# Altium schematic sheet STM32WB09_QFN32_RefBoard_SMD_2Layers.SchDoc(STM32WB09_QFN32_RefBoard_SMD_2Layers)
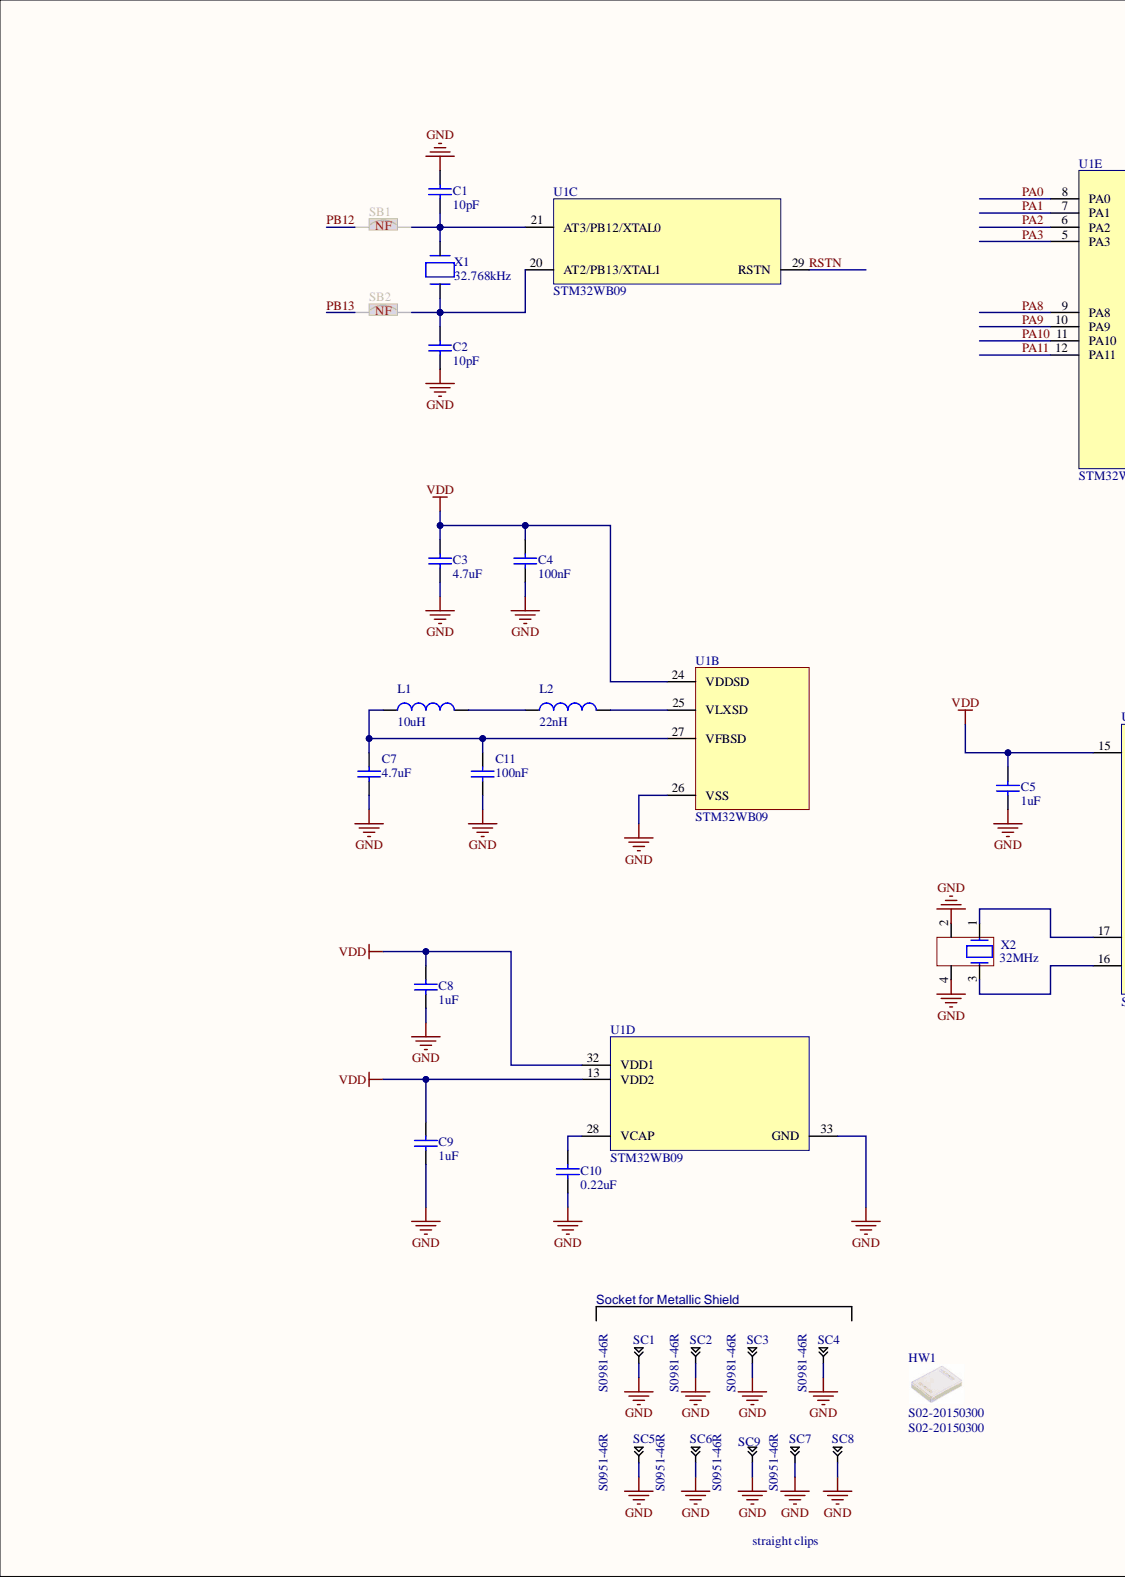
[diagram p0: STM32WB09_QFN32_RefBoard_SMD_2Layers.SchDoc, left]
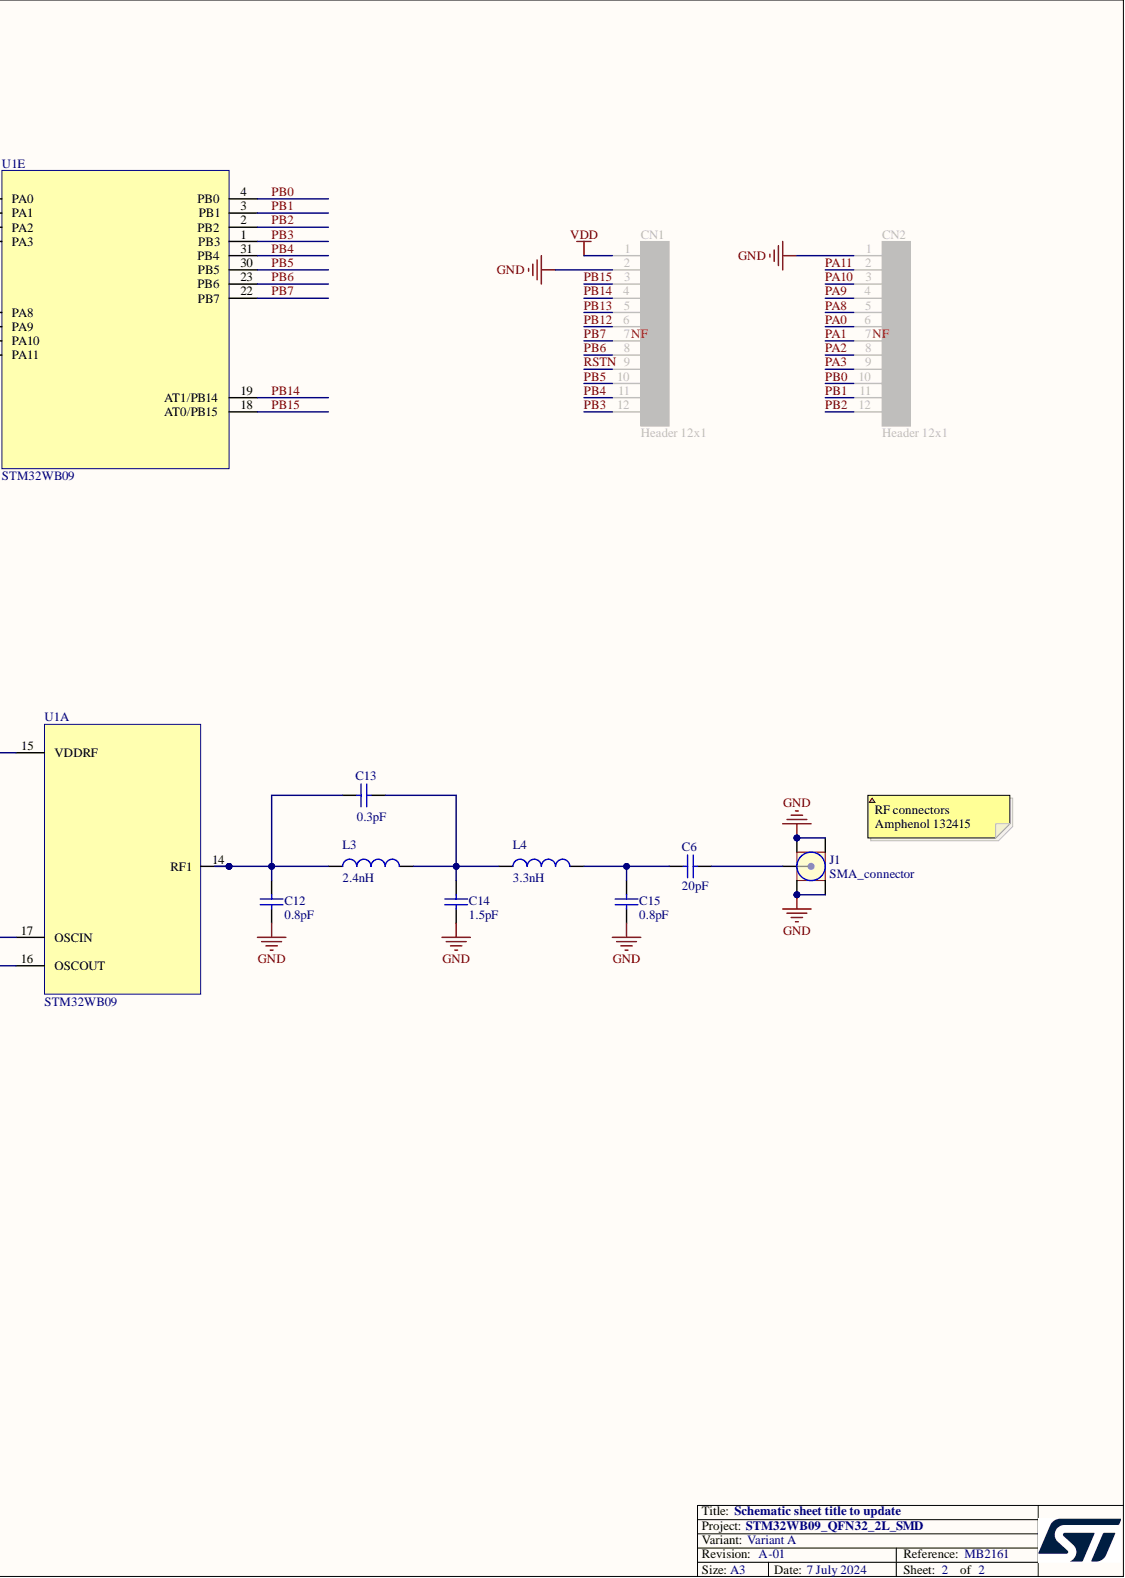
[diagram p1: STM32WB09_QFN32_RefBoard_SMD_2Layers.SchDoc, right]

## Parts
C1  value 10pF  [C0402]  (hidden comment: Capacitor)
C2  value 10pF  [C0402]  (hidden comment: Capacitor)
C3  value 4.7uF  [C0402]  (hidden comment: Capacitor)
C4  value 100nF  [C0201_H0P3]  (hidden comment: Capacitor)
C5  value 1uF  [C0402]  (hidden comment: Capacitor)
C6  value 20pF  [20p_capacitor_SMD_0201]  (hidden comment: Capacitor)
C7  value 4.7uF  [C0402]  (hidden comment: Capacitor)
C8  value 1uF  [C0402]  (hidden comment: Capacitor)
C9  value 1uF  [C0402]  (hidden comment: Capacitor)
C10  value 0.22uF  [C0402]  (hidden comment: Capacitor)
C11  value 100nF  [C0402]  (hidden comment: Capacitor)
C12  value 0.8pF  [0_8p_capacitor_SMD_0201]  (hidden comment: Capacitor)
C13  value 0.3pF  [0_5p_capacitor_SMD_0201]  (hidden comment: Capacitor)
C14  value 1.5pF  [1_5p_capacitor_SMD_0201]  (hidden comment: Capacitor)
C15  value 0.8pF  [0_8p_capacitor_SMD_0201]  (hidden comment: Capacitor)
CN1  Header 12x1  [CON_SAMTEC_TSW-112-07-X-S]
CN2  Header 12x1  [CON_SAMTEC_TSW-112-07-X-S]
HW1  S02-20150300  [SHIELD_HARWIN_S02-20150300_15X20_H3]
J1  SMA_connector  [SMA_AMPHENOL_132415]
L1  value 10uH  [IND_0805_MURATA_LQM21]  (hidden comment: Inductor)
L2  value 22nH  [C0402]  (hidden comment: Inductor)
L3  value 2.4nH  [2n4_inductor_SMD_0201]  (hidden comment: Inductor)
L4  value 3.3nH  [3n3_inductor_SMD_0201]  (hidden comment: Inductor)
SB1  SB_ON  [SOLDER-BRIDGE_R0402-0R]  (value not drawn)
SB2  SB_ON  [SOLDER-BRIDGE_R0402-0R]  (value not drawn)
SC1  value S0981-46R  Manufacturer_Part_Number: S0981-46R  [CLIP_HARWIN_S0981-46R]  (hidden comment: Shield-clip_S0951-46R)
SC2  value S0981-46R  Manufacturer_Part_Number: S0981-46R  [CLIP_HARWIN_S0981-46R]  (hidden comment: Shield-clip_S0951-46R)
SC3  value S0981-46R  Manufacturer_Part_Number: S0981-46R  [CLIP_HARWIN_S0981-46R]  (hidden comment: Shield-clip_S0951-46R)
SC4  value S0981-46R  Manufacturer_Part_Number: S0981-46R  [CLIP_HARWIN_S0981-46R]  (hidden comment: Shield-clip_S0951-46R)
SC5  value S0951-46R  Manufacturer_Part_Number: S0951-46R  [CLIP_HARWIN_S0951-46R]  (hidden comment: Shield-clip_S0951-46R)
SC6  value S0951-46R  Manufacturer_Part_Number: S0951-46R  [CLIP_HARWIN_S0951-46R]  (hidden comment: Shield-clip_S0951-46R)
SC7  value S0951-46R  Manufacturer_Part_Number: S0951-46R  [CLIP_HARWIN_S0951-46R]  (hidden comment: Shield-clip_S0951-46R)
SC8  Shield-clip_S0951-46R  [CLIP_HARWIN_S0951-46R]  (value not drawn)
SC9  value S0951-46R  Manufacturer_Part_Number: S0951-46R  [CLIP_HARWIN_S0951-46R]  (hidden comment: Shield-clip_S0951-46R)
U1  STM32WB09  [ICC_QFN32-EPAD_5X5_P050]  (parts A,B,C,D,E)
X1  value 32.768kHz  [CRY_NX2012SA_2P]  (hidden comment: XTAL_2_PINS)
X2  value 32MHz  [CRY_NX2016SA_4P]  (hidden comment: XTAL_4_PINS)

## Nets
GND: C1.2 C2.1 C3.1 C4.1 C5.1 C7.1 C8.1 C9.1 C10.1 C11.1 C12.1 C14.1 C15.1 CN1.2 CN2.1 J1.2 SC1.1 SC2.1 SC3.1 SC4.1 SC5.1 SC6.1 SC7.1 SC8.1 SC9.1 U1.26(VSS) U1.33(GND) X2.2 X2.4
VDD: C3.2 C4.2 C5.2 C8.2 C9.2 CN1.1 U1.13(VDD2) U1.15(VDDRF) U1.24(VDDSD) U1.32(VDD1)
PA0: CN2.6 U1.8(PA0)
PA1: CN2.7 U1.7(PA1)
PA2: CN2.8 U1.6(PA2)
PA3: CN2.9 U1.5(PA3)
PA8: CN2.5 U1.9(PA8)
PA9: CN2.4 U1.10(PA9)
PA10: CN2.3 U1.11(PA10)
PA11: CN2.2 U1.12(PA11)
PB0: CN2.10 U1.4(PB0)
PB1: CN2.11 U1.3(PB1)
PB2: CN2.12 U1.2(PB2)
PB3: CN1.12 U1.1(PB3)
PB4: CN1.11 U1.31(PB4)
PB5: CN1.10 U1.30(PB5)
PB6: CN1.8 U1.23(PB6)
PB7: CN1.7 U1.22(PB7)
PB12: CN1.6 SB1.1
PB13: CN1.5 SB2.1
PB14: CN1.4 U1.19(AT1/PB14)
PB15: CN1.3 U1.18(AT0/PB15)
RSTN: CN1.9 U1.29(RSTN)
NetC1_1: C1.1 SB1.2 U1.21(AT3/PB12/XTAL0) X1.1
NetC2_2: C2.2 SB2.2 U1.20(AT2/PB13/XTAL1) X1.2
NetC6_1: C6.1 C15.2 L4.2
NetC6_2: C6.2 J1.1
NetC7_2: C7.2 C11.2 L1.1 U1.27(VFBSD)
NetC10_2: C10.2 U1.28(VCAP)
NetC12_2: C12.2 C13.1 L3.1 U1.14(RF1)
NetC13_2: C13.2 C14.2 L3.2 L4.1
NetL1_2: L1.2 L2.1
NetL2_2: L2.2 U1.25(VLXSD)
NetU1_16: U1.16(OSCOUT) X2.3
NetU1_17: U1.17(OSCIN) X2.1

## Library data of the parts
C1 C2 = MCD-00015: Capacitor, MLCC, 10pF, 50V, NPO, +/-5%, 0402, T=0.5mm; Manufacturer: YAGEO; Manufacturer_Part_Number: CC0402JRNPO9BN100; Part_Preference: Recommended; Component_Country_Of_Origin: China; Database Table Name: MCD_PARTS$; ID: 2465; Order_Code_RS: 3019160RL; ShowFields: YES; Component Kind: Standard; ComponentLink1URL: http://tunmcdsvn.tun.st.com/Component_Documentation_Library/Trunk/Capacitor/YAGEO_NP0_Series; Internal_Part_Number: MCD-00015; Library Name: MCD_PARTS_database.DbLib; Order_Code_Digikey: 311-1014-1-ND; Order_Code_Farnell: 3019160RL; Package: 0402; Part_Type: Capacitor; Status: Released
C3 C7 = MCD-03431: Capacitor, MLCC, 4.7uF, X5R, 10V, +/-20%, 0402, T=0.5mm; Manufacturer: MURATA; Manufacturer_Part_Number: GRM155R61A475MEAAD; Part_Preference: Recommended; Component_Country_Of_Origin: China, Japan, Philippines, Singapore; Database Table Name: MCD_PARTS$; ID: 5547; Order_Code_RS: 172-6870; ShowFields: YES; Component Kind: Standard; ComponentLink1URL: http://tunmcdsvn.tun.st.com/Component_Documentation_Library/Trunk/Capacitor/MURATA_GRM_Series/GRM155R61A475MEAAD; Internal_Part_Number: MCD-03431; Library Name: MCD_PARTS_database.DbLib; Order_Code_Digikey: 90-14306-2-ND; Order_Code_Farnell: 2688503RL; Package: 0402; Part_Type: Capacitor; Status: Checked
C4 = MCD-01535: Capacitor, MLCC,100nF, 10V, X5R, +/-10%, 0201, T=0.3mm; Manufacturer: MURATA; Manufacturer_Part_Number: GRM033R61A104KE15; Part_Preference: Recommended; Component_Country_Of_Origin: Japan; Database Table Name: MCD_PARTS$; ID: 3635; Order_Code_RS: 790-2742; ShowFields: YES; Component Kind: Standard; ComponentLink1URL: http://tunmcdsvn.tun.st.com/Component_Documentation_Library/Trunk/Capacitor/MURATA_GRM_Series; Internal_Part_Number: MCD-01535; Library Name: MCD_PARTS_database.DbLib; Order_Code_Digikey: 490-5881-1-ND; Order_Code_Farnell: 2470450; Package: 0201; Part_Type: Capacitor; Status: Released
C5 C8 C9 = MCD-03432: Capacitor, MLCC, 1uF, X7R, 6.3V,+/- 10%, 0402, T=0.5mm; Manufacturer: MURATA; Manufacturer_Part_Number: GRM155R70J105KA12; Part_Preference: Recommended; Component_Country_Of_Origin: China, Japan, Philippines, Singapore; Database Table Name: MCD_PARTS$; ID: 5548; Order_Code_RS: 113-8711; ShowFields: YES; Component Kind: Standard; ComponentLink1URL: http://tunmcdsvn.tun.st.com/Component_Documentation_Library/Trunk/Capacitor/MURATA_GRM_Series/GRM155R70J105KA12; Internal_Part_Number: MCD-03432; Library Name: MCD_PARTS_database.DbLib; Order_Code_Digikey: NA; Order_Code_Farnell: NA; Package: 0402; Part_Type: Capacitor; Status: Checked
C6 = MCD-03543: Capacitor, MLCC, 20pF, 25V, COG, +/-2%, 0201, T=0.3mm; Manufacturer: MURATA; Manufacturer_Part_Number: GJM0335C1E200GB01; Part_Preference: Recommended; Component_Country_Of_Origin: Japan, Singapore; ID: 5659; ShowFields: YES; Component Kind: Standard; ComponentLink1URL: http://tunmcdsvn.tun.st.com/Component_Documentation_Library/Trunk/Capacitor/MURATA_GJM_Series; Internal_Part_Number: MCD-03543; Order_Code_Digikey: 490-GJM0335C1E200GB01DTR-ND; Order_Code_Farnell: 2994012; Order_Code_RS: N/A; Package: 0201; Part_Type: Capacitor; Status: Checked; Library Name: missing_parts.SchLib
C10 = MCD-03483: Capacitor, MLCC, 0.22uF, X5R, 6.3V, +/-10%, 0402, T=0.5mm; Manufacturer: YAGEO; Manufacturer_Part_Number: CC0402KRX5R5BB224; Part_Preference: Recommended; Component_Country_Of_Origin: China; Database Table Name: MCD_PARTS$; ID: 5599; Order_Code_RS: 3369131; ShowFields: YES; Component Kind: Standard; ComponentLink1URL: http://tunmcdsvn.tun.st.com/Component_Documentation_Library/Trunk/Capacitor/YAGEO_X5R_Series; Internal_Part_Number: MCD-03483; Library Name: MCD_PARTS_database.DbLib; Order_Code_Digikey: 311-1681-2-ND; Order_Code_Farnell: 3369131; Package: 0402; Part_Type: Capacitor; Status: Checked
C11 = MCD-03442: Capacitor, MLCC, 100nF, +/-10%, X7R, 25V, 0402, T=0.5mm; Manufacturer: MURATA; Manufacturer Part Number: GRM155R71E104KE14D; Part_Preference: Recommended; Component_Country_Of_Origin: China, Japan, Philippines, Singapore; Database Table Name: MCD_PARTS$; ID: 5558; Order_Code_RS: NA; ShowFields: YES; Component Kind: Standard; ComponentLink1URL: http://tunmcdsvn.tun.st.com/Component_Documentation_Library/Trunk/Capacitor/MURATA_GRM_Series/GRM155R71E104KE14; Internal_Part_Number: MCD-03442; Library Name: MCD_PARTS_database.DbLib; Manufacturer_Part_Number: GRM155R71E104KE14D; Order_Code_Digikey: 490-10698-2-ND; Order_Code_Farnell: NA; Package: 0402; Part_Type: Capacitor; Status: Checked
C12 C15 = MCD-02284_1: Multilayer Ceramic Capacitor, 0.8 pF, 25 V, ± 0.05pF, C0G (NP0), 0201 [0603 Metric]; Manufacturer: Murata; Manufacturer Part Number: GJM0335C1ER80WB01D; Material: 4241ConductorMaterial; Temperature Coefficient: 30ppm/°C; Category: Ceramic Capacitors; Component Kind: Standard; ComponentLink1URL: http://tunmcdsvn.tun.st.com/Component_Documentation_Library/Trunk/Capacitor/MURATA_GRM_Series; ComponentLink2Description: https://datasheet.ciiva.com/11616/product-11616837.pdf?src-supplier=Avnet; ComponentLink2URL: https://datasheet.ciiva.com/11616/product-11616837.pdf?src-supplier=Avnet; ComponentLink3Description: https://datasheet.ciiva.com/170/partnumbering-e-01-170845.pdf?src-supplier=Digi-Key; ComponentLink3URL: https://datasheet.ciiva.com/170/partnumbering-e-01-170845.pdf?src-supplier=Digi-Key; Manufacturer_Part_Number: GJM0335C1ER80WB01D; Package: 0201; Pricing 1: 1=0.072, 10=0.0302, 25=0.0296, 100=0.0178, 250=0.0176, 500=0.0175, 1000=0.0173, 3000=0.0172, 6000=0.0171, 15000=0.0169 (USD); RoHS: Yes; Stock 1: 23495; Supplier 1: Arrow Electronics; Supplier Part Number 1: GJM0335C1ER80WB01D; Library Name: missing_parts.SchLib
C13 = MCD-02284_4: 0201 0.3 pF 25 V ±0.05 pF Tolerance C0G SMT Multilayer Ceramic Capacitor; Manufacturer: Murata; Manufacturer Part Number: GJM0335C1ER30WB01D; Category: Ceramic Capacitors; Component Kind: Standard; ComponentLink1URL: http://tunmcdsvn.tun.st.com/Component_Documentation_Library/Trunk/Capacitor/MURATA_GRM_Series; ComponentLink2Description: https://datasheet.ciiva.com/11617/product-11617172.pdf?src-supplier=Avnet; ComponentLink2URL: https://datasheet.ciiva.com/11617/product-11617172.pdf?src-supplier=Avnet; ComponentLink3Description: https://datasheet.ciiva.com/29541/partnumbering-e-01-29541903.pdf?src-supplier=Digi-Key; ComponentLink3URL: https://datasheet.ciiva.com/29541/partnumbering-e-01-29541903.pdf?src-supplier=Digi-Key; ComponentLink4Description: https://datasheet.ciiva.com/29541/partnumbering-e-01-29541902.pdf?src-supplier=Digi-Key; ComponentLink4URL: https://datasheet.ciiva.com/29541/partnumbering-e-01-29541902.pdf?src-supplier=Digi-Key; ComponentLink5Description: https://datasheet.ciiva.com/170/gjm0335c1er30wb01-170840.pdf?src-supplier=Digi-Key; ComponentLink5URL: https://datasheet.ciiva.com/170/gjm0335c1er30wb01-170840.pdf?src-supplier=Digi-Key; ComponentLink6Description: https://datasheet.ciiva.com/11617/product-11617570.pdf?src-supplier=Avnet; ComponentLink6URL: https://datasheet.ciiva.com/11617/product-11617570.pdf?src-supplier=Avnet; ComponentLink7Description: https://datasheet.ciiva.com/16467/product-16467435.pdf?src-supplier=Future+Electronics; ComponentLink7URL: https://datasheet.ciiva.com/16467/product-16467435.pdf?src-supplier=Future+Electronics; ComponentLink8Description: https://datasheet.ciiva.com/29541/partnumbering-e-01-29541493.pdf?src-supplier=Digi-Key; ComponentLink8URL: https://datasheet.ciiva.com/29541/partnumbering-e-01-29541493.pdf?src-supplier=Digi-Key; ComponentLink9Description: https://datasheet.ciiva.com/29541/partnumbering-e-01-29541494.pdf?src-supplier=Digi-Key; ComponentLink9URL: https://datasheet.ciiva.com/29541/partnumbering-e-01-29541494.pdf?src-supplier=Digi-Key; ComponentLink10Description: https://datasheet.ciiva.com/29541/partnumbering-e-01-29541495.pdf?src-supplier=Digi-Key; ComponentLink10URL: https://datasheet.ciiva.com/29541/partnumbering-e-01-29541495.pdf?src-supplier=Digi-Key; Manufacturer_Part_Number: GJM0335C1ER30WB01D; Package: 0201; Pricing 1: 1=0.14, 10=0.096, 50=0.0574, 100=0.0451, 500=0.0333, 1000=0.02757, 2500=0.02566, 5000=0.02347 (USD); RoHS: Yes; Stock 1: 304885; Supplier 1: Digi-Key; Supplier Part Number 1: 490-8073-1-ND; Library Name: missing_parts.SchLib
C14 = MCD-02284_3: Multilayer Ceramic Capacitor, 1.5 pF, 25 V, ± 0.1pF, C0G (NP0), 0201 [0603 Metric]; Manufacturer: Murata; Manufacturer Part Number: GJM0335C1E1R5BB01D; Voltage Rating: 25V; Case Code (Imperial): 0201; Case Code (Metric): 0603; Case/Package: 0201; Category: Ceramic Capacitors; Component Kind: Standard; ComponentLink1URL: http://tunmcdsvn.tun.st.com/Component_Documentation_Library/Trunk/Capacitor/MURATA_GRM_Series; ComponentLink2Description: https://datasheet.ciiva.com/11616/product-11616837.pdf?src-supplier=Avnet; ComponentLink2URL: https://datasheet.ciiva.com/11616/product-11616837.pdf?src-supplier=Avnet; ComponentLink3Description: https://datasheet.ciiva.com/170/partnumbering-e-01-170845.pdf?src-supplier=Digi-Key; ComponentLink3URL: https://datasheet.ciiva.com/170/partnumbering-e-01-170845.pdf?src-supplier=Digi-Key; ComponentLink4Description: https://datasheet.ciiva.com/11615/product-11615773.pdf?src-supplier=Avnet; ComponentLink4URL: https://datasheet.ciiva.com/11615/product-11615773.pdf?src-supplier=Avnet; ComponentLink5Description: https://datasheet.ciiva.com/18183/getdatasheetpartid-480423-18183785.pdf?src-supplier=Verical; ComponentLink5URL: https://datasheet.ciiva.com/18183/getdatasheetpartid-480423-18183785.pdf?src-supplier=Verical; Construction: Flat; Depth: 0.3mm; Dielectric: C0G; Height: 0.3mm; Lead Free: Lead Free; Length: 0.6mm; Manufacturer_Part_Number: GJM0335C1E1R5BB01D; Max Operating Temperature: 125°C; Min Operating Temperature: -55°C; Mounting Technology: Surface Mount; Package: 0201; Package Quantity: 15000; Packaging: Tape and Reel; Pricing 1: 1=0.1, 10=0.069, 50=0.0376, 100=0.0308, 500=0.02198, 1000=0.01727, 2500=0.0157, 5000=0.01444 (USD); Radiation Hardening: No; RoHS: Yes; RoHS Compliant: Yes; Series: GJM; Stock 1: 1582621; Supplier 1: Digi-Key; Supplier Part Number 1: 490-11175-1-ND; Termination: SMD/SMT; Thickness: 0.013inch; Voltage Rating (DC): 25V; Width: 0.012inch; Library Name: missing_parts.SchLib
CN1 CN2 = MCD-01795: Header, 12x1, 2.54mm, VR, TH, T=5.84mm/2.54mm; Manufacturer: SAMTEC; Manufacturer_Part_Number: TSW-112-07-T-S; Part_Preference: Recommended; Part_Type: Connector; Component_Country_Of_Origin: China, Costa Rica, Malaysia, United States, Vietnam; Database Table Name: MCD_PARTS$; ID: 3895; Order_Code_RS: 180-2023; ShowFields: YES; Component Kind: Standard; ComponentLink1URL: http://tunmcdsvn.tun.st.com/Component_Documentation_Library/Trunk/Connector/SAMTEC_TSW_Series/; Internal_Part_Number: MCD-01795; Library Name: MCD_PARTS_database.DbLib; Order_Code_Digikey: MTSW-112-07-T-S-007-ND; Order_Code_Farnell: 2035692; Status: Released; Value: Header 12x1
HW1 = MCD-03126: RFI shield can, 20x15x3mm; Manufacturer: HARWIN; Part_Preference: Recommended; Part_Type: Misc; Component_Country_Of_Origin: UK°; Database Table Name: MCD_PARTS$; ID: 5242; Order_Code_RS: 161-3687; ShowFields: YES; Component Kind: Standard; ComponentLink1URL: http://tunmcdsvn.tun.st.com/Component_Documentation_Library/Trunk/Misc/HARWIN_S02-20150300; Internal_Part_Number: MCD-03126; Library Name: MCD_PARTS_database.DbLib; Manufacturer_Part_Number: S02-20150300; Order_Code_Digikey: 952-2632-ND; Order_Code_Farnell: 3755471; Package: 20x15x3mm; Status: Checked; Value: S02-20150300
J1 = SMA_connector: Rf Connector, Sma End Launch Recept Jack For .032" Pcb / Individual Bag; Manufacturer: Amphenol Connex; Manufacturer Part Number: 132415; Note: Replacement only with Johnson 142-0791-811; Category: RF / Coaxial Connectors; Component Kind: Standard; ComponentLink1Description: https://datasheet.ciiva.com/13668/132415-57564-13668389.pdf?src-supplier=Mouser; ComponentLink1URL: https://datasheet.ciiva.com/13668/132415-57564-13668389.pdf?src-supplier=Mouser; Manufacturer_Part_Number: 132415; Pricing 1: 1=12.78, 10=11.327, 25=10.8916, 50=10.4558, 100=9.875, 250=9.00364, 500=8.42276, 1000=7.7257 (USD); RoHS: Yes; Stock 1: 22; Supplier 1: Digi-Key; Supplier Part Number 1: 132415-ND; Library Name: missing_parts.SchLib
L1 = MCD-03438: Inductor, MLCC, 10uH, +/-20%, 300mA, 845mR, 0805, T=1.45mm; Manufacturer: MURATA; Manufacturer_Part_Number: LQM21DN100M70L; Part_Preference: Recommended; Component_Country_Of_Origin: Japan; Database Table Name: MCD_PARTS$; ID: 5554; Order_Code_RS: NA; ShowFields: YES; Component Kind: Standard; ComponentLink1URL: http://tunmcdsvn.tun.st.com/Component_Documentation_Library/Trunk/Inductor/MURATA_LQM21DN100M70L; Internal_Part_Number: MCD-03438; Library Name: MCD_PARTS_database.DbLib; Order_Code_Digikey: 490-LQM21DN100M70LTR-ND; Order_Code_Farnell: NA; Package: 0805; Part_Type: Inductor; Status: Checked
L2 = MCD-03439: Inductor, MLCC, 22nH, +/-2%, 350mA, 0.58R, 0402, T=1.45mm; Manufacturer: MURATA; Manufacturer_Part_Number: LQG15HN22NG02D; Part_Preference: Recommended; Component_Country_Of_Origin: Japan; Database Table Name: MCD_PARTS$; ID: 5555; Order_Code_RS: 3354168; ShowFields: YES; Component Kind: Standard; ComponentLink1URL: http://tunmcdsvn.tun.st.com/Component_Documentation_Library/Trunk/Inductor/MURATA_LQG15HN22NG02D; Internal_Part_Number: MCD-03439; Library Name: MCD_PARTS_database.DbLib; Order_Code_Digikey: 490-LQG15HN22NG02DTR-ND; Order_Code_Farnell: 3354168; Package: 0402; Part_Type: Inductor; Status: Checked
L3 = MCD-03552: Inductor RF Chip Thin Film 2.4nH 0.1nH 500MHz 14Q-Factor 500mA 200mOhm DCR 0201 Paper T/R; Manufacturer: Murata; Manufacturer Part Number: LQP03TN2N4B02D; Category: Fixed Inductors; Component Kind: Standard; ComponentLink1URL: http://tunmcdsvn.tun.st.com/Component_Documentation_Library/Trunk/Inductor/MURATA_LQP03TG_Series/LQP03TG0N5B02D; ComponentLink2Description: https://datasheet.ciiva.com/29692/s0084e-29692446.pdf?src-supplier=Digi-Key; ComponentLink2URL: https://datasheet.ciiva.com/29692/s0084e-29692446.pdf?src-supplier=Digi-Key; ComponentLink3Description: https://datasheet.ciiva.com/16444/product-16444478.pdf?src-supplier=Future+Electronics; ComponentLink3URL: https://datasheet.ciiva.com/16444/product-16444478.pdf?src-supplier=Future+Electronics; ComponentLink4Description: https://datasheet.ciiva.com/14826/1750lqp03tn2n4b0223-14826711.pdf?src-supplier=Arrow+Electronics; ComponentLink4URL: https://datasheet.ciiva.com/14826/1750lqp03tn2n4b0223-14826711.pdf?src-supplier=Arrow+Electronics; Manufacturer_Part_Number: LQP03TN2N4B02D; Package: 0201; Pricing 1: 1=0.1, 10=0.041, 25=0.0364, 50=0.031, 100=0.0273, 250=0.025, 500=0.02272, 1000=0.01909, 2500=0.01636 (USD); RoHS: Yes; Stock 1: 40543; Supplier 1: Digi-Key; Supplier Part Number 1: 490-6724-1-ND; Library Name: missing_parts.SchLib
L4 = MCD-03552_1: Inductor RF Chip Thin Film 3.3nH 0.1nH 500MHz 14Q-Factor 450mA 250mOhm DCR 0201 Paper T/R; Manufacturer: Murata; Manufacturer Part Number: LQP03TN3N3B02D; Category: Fixed Inductors; Component Kind: Standard; ComponentLink1URL: http://tunmcdsvn.tun.st.com/Component_Documentation_Library/Trunk/Inductor/MURATA_LQP03TG_Series/LQP03TG0N5B02D; ComponentLink2Description: https://datasheet.ciiva.com/29692/s0084e-29692446.pdf?src-supplier=Digi-Key; ComponentLink2URL: https://datasheet.ciiva.com/29692/s0084e-29692446.pdf?src-supplier=Digi-Key; ComponentLink3Description: https://datasheet.ciiva.com/16444/product-16444478.pdf?src-supplier=Future+Electronics; ComponentLink3URL: https://datasheet.ciiva.com/16444/product-16444478.pdf?src-supplier=Future+Electronics; ComponentLink4Description: https://datasheet.ciiva.com/14826/1750lqp03tn2n4b0223-14826711.pdf?src-supplier=Arrow+Electronics; ComponentLink4URL: https://datasheet.ciiva.com/14826/1750lqp03tn2n4b0223-14826711.pdf?src-supplier=Arrow+Electronics; ComponentLink5Description: https://datasheet.ciiva.com/4976/product-4976199.pdf?src-supplier=Mouser; ComponentLink5URL: https://datasheet.ciiva.com/4976/product-4976199.pdf?src-supplier=Mouser; Manufacturer_Part_Number: LQP03TN3N3B02D; Package: 0201; Pricing 1: 1=0.1, 10=0.041, 25=0.0364, 50=0.031, 100=0.0273, 250=0.025, 500=0.02272, 1000=0.01909, 2500=0.01636 (USD); RoHS: Yes; Stock 1: 987695; Supplier 1: Digi-Key; Supplier Part Number 1: 490-6728-1-ND; Library Name: missing_parts.SchLib
SB1 SB2 = MCD-01618: Resistor, 0R, SB0402, 5%, 0.063W; Manufacturer: YAGEO; Manufacturer_Part_Number: RC0402JR-070RL; Part_Preference: Recommended; Component_Country_Of_Origin: China; Database Table Name: MCD_PARTS$; ID: 3718; Order_Code_RS: 618-5804; ShowFields: YES; Component Kind: Standard; ComponentLink1URL: http://tunmcdsvn.tun.st.com/Component_Documentation_Library/Trunk/Resistor/YAGEO_RC0402_Series; Internal_Part_Number: MCD-01618; Library Name: MCD_PARTS_database.DbLib; Order_Code_Digikey: 311-0.0JRTR-ND; Order_Code_Farnell: 2363467; Package: 0402; Part_Type: Resistor; Status: Released; Value: 0R
SC1-SC4 = MCD-02164: Shield clip Harwin S0981-46R to fix RF shielded cavity; Part_Preference: Recommended; Part_Type: Mechanical; Component_Country_Of_Origin: South Korea; Database Table Name: MCD_PARTS$; ID: 4264; Order_Code_RS: 161-3702; ShowFields: YES; Component Kind: Standard; ComponentLink1URL: http://tunmcdsvn.tun.st.com/Component_Documentation_Library/Trunk/Misc/HARWIN_S0981-46R; Internal_Part_Number: MCD-02164; Library Name: MCD_PARTS_database.DbLib; Manufacturer: HARWIN; Order_Code_Digikey: 952-2518-1-ND; Order_Code_Farnell: 2361678; Package: 0.8x4.7x1.4mm; Status: Released
SC5-SC9 = MCD-01587: RFI shield clip mini TIN SMD; Manufacturer: HARWIN; Part_Preference: Recommended; Part_Type: Mechanical; Component_Country_Of_Origin: South Korea; Database Table Name: MCD_PARTS$; ID: 3687; ShowFields: YES; Component Kind: Standard; ComponentLink1URL: http://tunmcdsvn.tun.st.com/Component_Documentation_Library/Trunk/Misc/HARWIN_S0951-46R; Internal_Part_Number: MCD-01587; Library Name: MCD_PARTS_database.DbLib; Order_Code_Digikey: 952-2669-1-ND; Order_Code_Farnell: 2490970; Order_Code_RS: N/A; Package: 1.1x3.9mm; Status: Released
  SC8: Manufacturer_Part_Number: S0951-46R
U1 = MCD-03444: Bluetooth® Low Energy wireless SoC; Manufacturer: STMICROELECTRONICS; Manufacturer_Part_Number: STM32WB09KEV7; Part_Preference: Recommended; Part_Type: IC; Component_Country_Of_Origin: Unknown; Database Table Name: MCD_PARTS$; ID: 5560; Note: Provided by ST; ShowFields: YES; Component Kind: Standard; ComponentLink1URL: http://tunmcdsvn.tun.st.com/Component_Documentation_Library/Trunk/IC/STMICROELECTRONICS_BLUENRG-372AC; Internal_Part_Number: MCD-03444; Library Name: MCD_PARTS_database.DbLib; Order_Code_Digikey: N/A; Order_Code_Farnell: N/A; Order_Code_RS: N/A; Package: QFN32; Status: Checked; Value: STM32WB09
X1 = MCD-01445: Crystal, 32.768kHz, 7pF, +/-20ppm, 80K, 2.05x1.2mm; BMS_Part_Number: 9157043; Manufacturer: NDK; Manufacturer_Part_Number: NX2012SA-32.768KHZ-EXS00A-MU00389; Part_Preference: Recommended; Part_Type: Crystal_Osc; Component_Country_Of_Origin: Unknown; Database Table Name: MCD_PARTS$; ID: 3545; ShowFields: YES; Component Kind: Standard; ComponentLink1URL: http://tunmcdsvn.tun.st.com/Component_Documentation_Library/Trunk/Crytal_Oscillator/NDK_NX2012SA_Series; Internal_Part_Number: MCD-01445; Library Name: MCD_PARTS_database.DbLib; Order_Code_Digikey: 644-1350; Order_Code_Farnell: N/A; Order_Code_RS: N/A; Package: 2.05x1.2mm; Status: Checked
X2 = MCD-01446: Crystal, 32MHz, 8pF, +/-10ppm, 60R, 2x1.6mm; BMS_Part_Number: 9157042; Manufacturer: NDK; Manufacturer_Part_Number: NX2016SA-32MHZ-EXS00A-CS06654; Part_Preference: Recommended; Part_Type: Crystal_Osc; Component_Country_Of_Origin: Unknown; Database Table Name: MCD_PARTS$; ID: 3546; ShowFields: YES; Component Kind: Standard; ComponentLink1URL: http://tunmcdsvn.tun.st.com/Component_Documentation_Library/Trunk/Crytal_Oscillator/NDK_NX2016SA_Series; Internal_Part_Number: MCD-01446; Library Name: MCD_PARTS_database.DbLib; Order_Code_Digikey: 644-1373; Order_Code_Farnell: N/A; Order_Code_RS: N/A; Package: 2x1.6mm; Status: Checked
